# Altium schematic sheet Memory.SchDoc
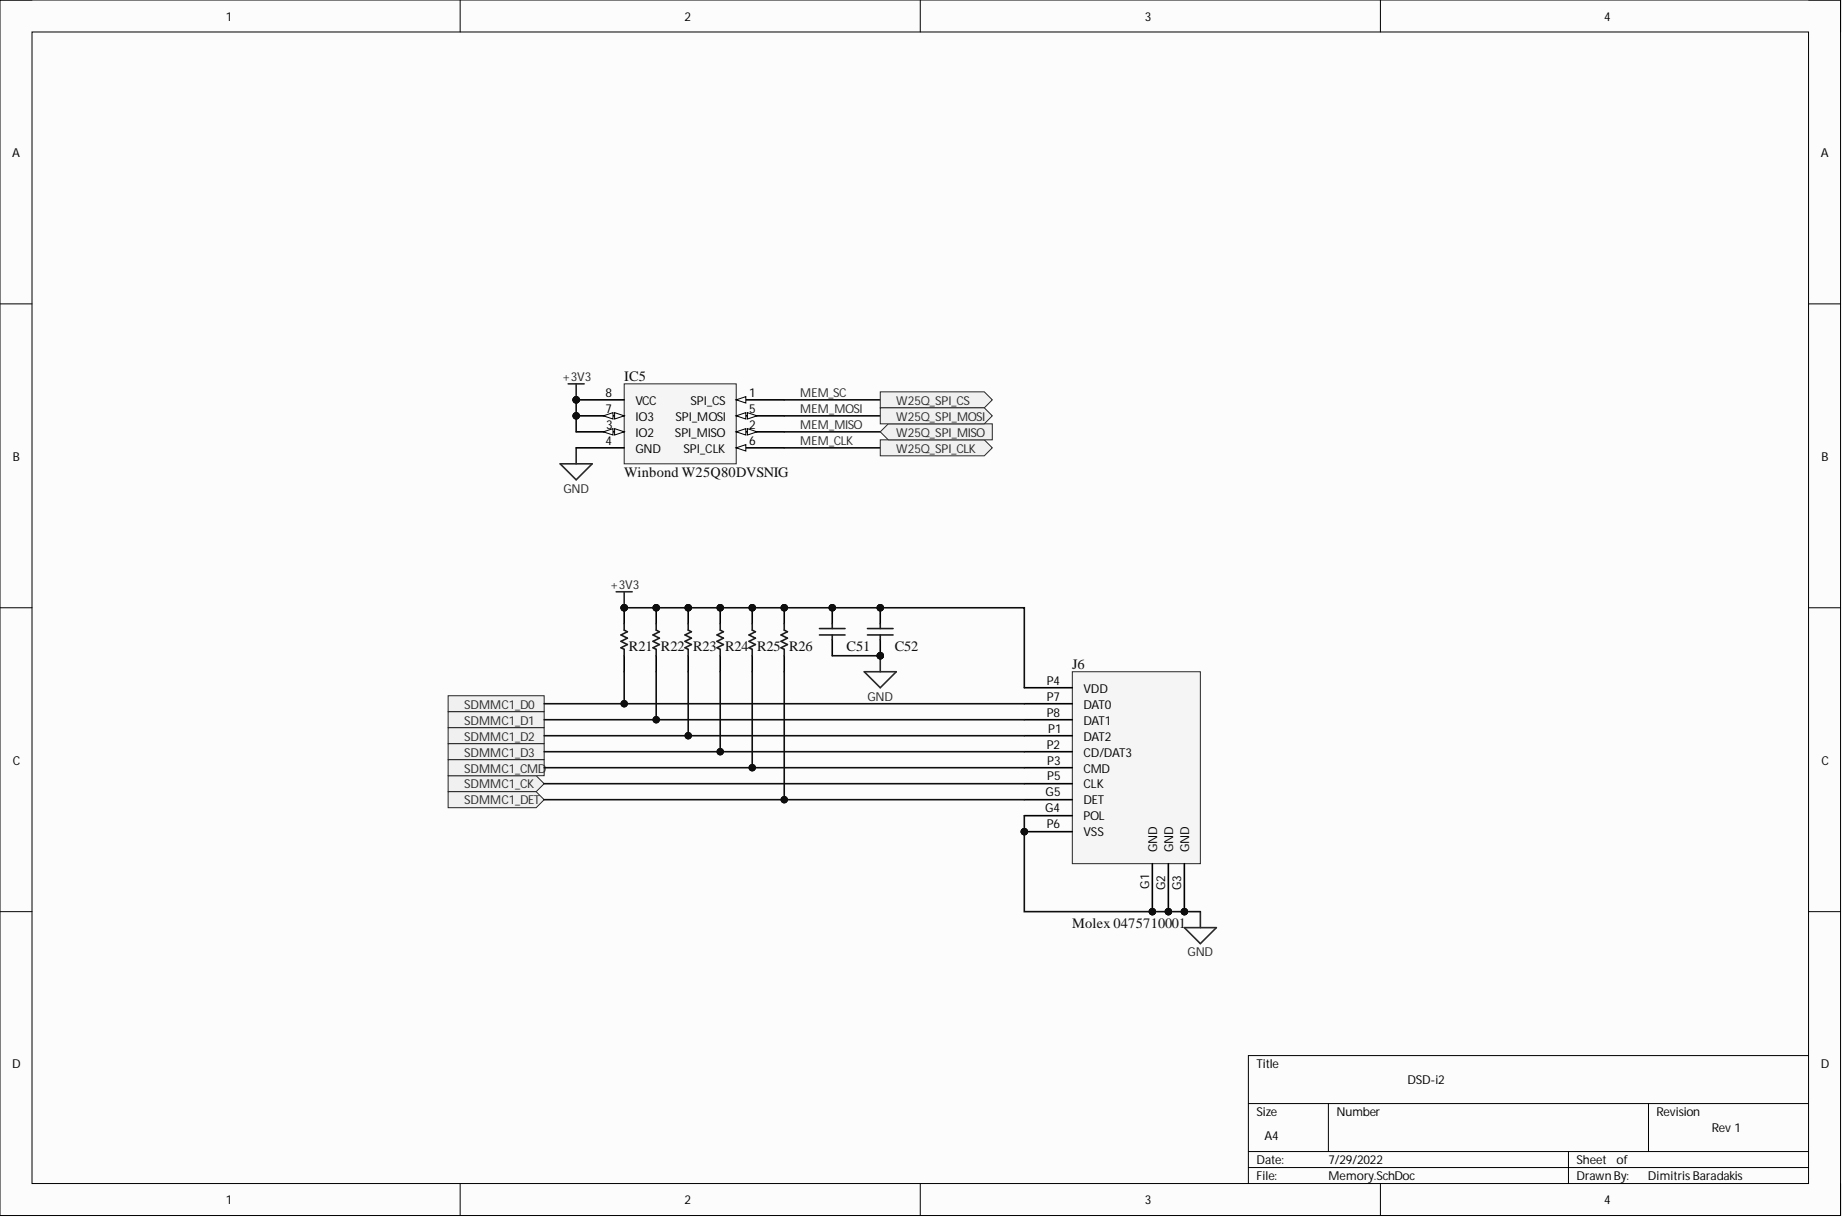
[diagram p0: Memory.SchDoc]

## Parts
C51  Samsung CL05A475MP5NRNC  [PCB-ly623x0ckhe065kxsmmc-1]  (value not drawn)
C52  Taiyo Yuden LMK063BJ104KP-F  [PCB-0002-00713-1]  (value not drawn)
IC5  Winbond W25Q80DVSNIG  Manufacturer Part Number: W25Q80DVSNIG  [PCB-blwgg9eecld4r4eupjc6-1]
J6  Molex 0475710001  Manufacturer: Molex  Manufacturer Part Number: 0475710001  [PCB-gwct26a1sfovcgvkigvj-1]
R21  Bourns CR0201AJW-103GLF  [Bourns CR0201AJW-103GLF-Footprint-1]  (value not drawn)
R22  Bourns CR0201AJW-103GLF  [Bourns CR0201AJW-103GLF-Footprint-1]  (value not drawn)
R23  Bourns CR0201AJW-103GLF  [Bourns CR0201AJW-103GLF-Footprint-1]  (value not drawn)
R24  Bourns CR0201AJW-103GLF  [Bourns CR0201AJW-103GLF-Footprint-1]  (value not drawn)
R25  Bourns CR0201AJW-103GLF  [Bourns CR0201AJW-103GLF-Footprint-1]  (value not drawn)
R26  Bourns CR0201AJW-103GLF  [Bourns CR0201AJW-103GLF-Footprint-1]  (value not drawn)

## Ports
SDMMC1_CK, SDMMC1_CMD, SDMMC1_D0, SDMMC1_D1, SDMMC1_D2, SDMMC1_D3, SDMMC1_DET, W25Q_SPI_CLK, W25Q_SPI_CS, W25Q_SPI_MISO, W25Q_SPI_MOSI

## Nets
+3V3: C51.2 C52.2 IC5.3(IO2) IC5.7(IO3) IC5.8(VCC) J6.P4(VDD) R21.2 R22.2 R23.2 R24.2 R25.2 R26.2
GND: C51.1 C52.1 IC5.4(GND) J6.G1(GND) J6.G2(GND) J6.G3(GND) J6.G4(POL) J6.P6(VSS)
MEM_CLK: IC5.6(SPI_CLK) ; port W25Q_SPI_CLK
MEM_MISO: IC5.2(SPI_MISO) ; port W25Q_SPI_MISO
MEM_MOSI: IC5.5(SPI_MOSI) ; port W25Q_SPI_MOSI
MEM_SC: IC5.1(SPI_CS) ; port W25Q_SPI_CS
SDMMC1_CK: J6.P5(CLK) ; port SDMMC1_CK
SDMMC1_CMD: J6.P3(CMD) R25.1 ; port SDMMC1_CMD
SDMMC1_D0: J6.P7(DAT0) R21.1 ; port SDMMC1_D0
SDMMC1_D1: J6.P8(DAT1) R22.1 ; port SDMMC1_D1
SDMMC1_D2: J6.P1(DAT2) R23.1 ; port SDMMC1_D2
SDMMC1_D3: J6.P2(CD/DAT3) R24.1 ; port SDMMC1_D3
SDMMC1_DET: J6.G5(DET) R26.1 ; port SDMMC1_DET

## Library data of the parts
C51 = CMP-45408d1ae5db9a87-4: Cap Ceramic 4.7uF 10V X5R 20% SMD 0402 85°C Paper T/R; Lead-Free Status: Lead Free; Component Kind: Standard; Library Name: My Personal Space; Manufacturer Part Number: CL05A475MP5NRNC; Octopart URL: https://octopart.com/cl05a475mp5nrnc-samsung-22054397; OctopartID: 45408d1ae5db9a87; RoHS: Compliant
C52 = CMP-e92820824df736ef-2: 0201 0.1 uF 10 V ±10% Tolerance X5R SMT Multilayer Ceramic Capacitor; Capacitance: 100 nF; Lead-Free Status: Lead Free; Voltage Rating (DC): 10.0 V; Capacitance Tolerance: ±10%; Case/Package: 0201; Component Kind: Standard; Dielectric Characteristic: X5R; Halogen Free Status: Halogen Free; Library Name: My Personal Space; Lifecycle Status: Active; Manufacturer Part Number: LMK063BJ104KP-F; Mounting Style: Surface Mount; Number of Pins: 2; Octopart URL: https://octopart.com/lmk063bj104kp-f-taiyo+yuden-10518969; OctopartID: e92820824df736ef; Operating Temperature: -55.0 °C to 85.0 °C; Packaging: Cut Tape (CT); RoHS: Compliant
IC5 = CMP-3d5cf6044865e29b-3: NOR Flash Serial (SPI, Dual SPI, Quad SPI) 2.5V/3V/3.3V 8M-bit 1M x 8 6ns 8-Pin SOIC; Component Kind: Standard; Library Name: My Personal Space; Octopart URL: https://octopart.com/w25q80dvsnig-winbond-38948959; OctopartID: 3d5cf6044865e29b; RoHS: Compliant
J6 = CMP-423b8a3e94869dfc-1: Conn Micro Sd Card Push-Pull r/a; Component Kind: Standard; Library Name: My Personal Space; Lifecycle Status: Not Listed by Manufacturer; Octopart URL: http://octopart.com/0475710001-molex-17822683; OctopartID: 423b8a3e94869dfc
R21-R26 = CMP-0c15c370377fd3d2-2: Thick Film Chip Resistor; 0201 10k 5% 50mW TC200; Component Kind: Standard; Library Name: My Personal Space; Manufacturer Part Number: CR0201AJW-103GLF; Octopart Url: https://octopart.com/cr0201ajw-103glf-bourns-107945484; OctopartID: 0c15c370377fd3d2
